# Altium schematic sheet RUMBA_plus.SchDoc (continued)

## Library data of the parts
5V 12V FAN0 FAN1 PS_ON PWR PWR2 = Header 2: Header, 2-Pin; Manufacturer 1: Generic Header; Note: Approved sub OK; LatestRevisionDate: 17-Jul-2002; LatestRevisionNote: Re-released for DXP Platform.; Signal Integrity: Connector; Library Name: RUMBA_v2.SCHLIB; Publisher: Altium Limited
  5V 12V FAN0 FAN1 PWR PWR2: Component Kind: Standard
C1 C2 C21-C25 C30 C31 = CapE-6.3-100uF-35V: Polarized Capacitor (Surface Mount); Note: Approved sub OK; Component Kind: Standard; Published: 8-Jun-2000; Revision: July-2002: Re-released for DXP Platform.; Signal Integrity: Cap Pol; Simulation: CAP; Supplier 1: Digi-Key; Supplier Part Number 1: PCE5016CT-ND; Library Name: RUMBA_v2.SCHLIB; Publisher: Altium Limited
C3 C9 C12 C26-C29 C35 C36 = CAPC_1u_0603_X7R_16V_10%: Capacitor SMD Cer 1uF 10% 16V X7R 0603; Manufacturer 1: TDK Corporation; Manufacturer Part Number 1: C1608X7R1C105K; Material: ceramic; Ratings: 16V; X7R; SCDType: spec; Component Kind: Standard; ComponentLink1Description: http://product.tdk.com/capacitor/mlcc/en/documents/mlcc_commercial_general_en.pdf; ComponentLink1URL: http://product.tdk.com/capacitor/mlcc/en/documents/mlcc_commercial_general_en.pdf; Library Name: PBL - Capacitors.SCHLIB; Note: Approved sub OK; Package / Case: 0603 (1608 metric); Supplier 1: Digi-Key; Supplier Part Number 1: 445-1604-1-ND; Tolerance: ±10%
C4-C7 C11 C13 C16 C18 = CapC-0603-100nF: Capacitor (Semiconductor SIM Model); Note: Approved sub OK; Component Kind: Standard; Published: 8-Jun-2000; Revision: July-2002: Re-released for DXP Platform.; Signal Integrity: Cap Semi; Simulation: CAP; Supplier 1: Digi-Key; Supplier Part Number 1: 311-1344-1-ND; Library Name: RUMBA_v2.SCHLIB; Publisher: Altium Limited
C8 C14 C15 C19 C20 = CapC-0603-22pF: Capacitor (Semiconductor SIM Model); Note: Approved sub OK; Component Kind: Standard; Published: 8-Jun-2000; Revision: July-2002: Re-released for DXP Platform.; Signal Integrity: Cap Semi; Simulation: CAP; Supplier 1: Digi-Key; Supplier Part Number 1: 478-6204-1-ND; Library Name: RUMBA_v2.SCHLIB; Publisher: Altium Limited
D1 D6 D7 D9-D14 = DIODE_100V_1.5A_SMA: Diode SMD 100V 1.5A SMA; Manufacturer 1: Diodes Inc; Manufacturer Part Number 1: S2BA-13-F; Diode Type: Standard; Material: Si; Note: Approved sub OK; SCDType: source; Value: Vf = 1.15V @ 1.5A (Max); Component Kind: Standard; Library Name: PBL - Diodes.SCHLIB; Package / Case: DO-214AC, SMA; Ratings: 100V; 1.5A; Supplier 1: Digi-Key; Supplier Part Number 1: S2BA-FDICT-ND
D2 D3 = DIODE_75V_500mW: Diode SMD 300mA 0.5W SOD323; Manufacturer: Micro Commercial Co; Manufacturer Part Number: 1N4148WX-TP; Diode Type: Standard; Material: Si; Note: Approved sub OK; Ratings: 75V; 300mA; 0.5W; SCDType: source; Value: Vf=1V @ 50mA; Component Kind: Standard; Library Name: PBL - Diodes.SCHLIB; Package / Case: SOD323; Supplier 1: Digi-Key; Supplier Part Number 1: 1N4148WXTPMSCT-ND
D4 = Diode Schottky 2A 20V Dual Common Cathode: Default Diode; Code - JEDEC: DO-214-AB; Note: Approved sub OK; VALUE: PMEG2020CPA,115; Published: 8-Jun-2000; Revision: July-2002: Re-released for DXP Platform.; Signal Integrity: Diode; Simulation: DIODE; Supplier 1: Digi-Key; Supplier Part Number 1: 1727-5194-1-ND; Library Name: RUMBA_v2.SCHLIB; Publisher: Altium Limited
D5 = Diode Schottky 2A 40V: Default Diode; Code - JEDEC: DO-214-AB; Note: Approved sub OK; Component Kind: Standard; Published: 8-Jun-2000; Revision: July-2002: Re-released for DXP Platform.; Signal Integrity: Diode; Simulation: DIODE; Supplier 1: Digi-Key; Supplier Part Number 1: DB2W40200LCT-ND; Library Name: picoprint_library.SCHLIB; Publisher: Altium Limited
E0 E1 X Y ZD ZG = A4988BREAKOUT: Manufacturer 1: Generic Header; Note: Approved sub OK; Component Kind: Standard; Description: Header, 8-Pin, Female; Library Name: RUMBA_v2.SCHLIB
E0_MOT E1_MOT E2_MOT X_MOT Y_MOT Z_MOT = Header 4_1: Header, 4-Pin; Note: Approved sub OK; LatestRevisionDate: 17-Jul-2002; LatestRevisionNote: Re-released for DXP Platform.; Signal Integrity: Connector; Supplier 1: Digi-Key; Supplier Part Number 1: 3M9449-ND; Library Name: RUMBA_v2.SCHLIB; Publisher: Altium Limited
E0_MOT_A E1_MOT_A E2_MOT_A X_MOT_A Y_MOT_A Z_MOT_A = ScrewTerminal-3.5mm-4Way: Screw Terminal 3.5mm 4-Way; Note: Approved sub OK; Component Kind: Standard; LatestRevisionDate: 17-Jul-2002; LatestRevisionNote: Re-released for DXP Platform.; Signal Integrity: Connector; Supplier 1: Digi-Key; Supplier Part Number 1: 277-5744-ND; Library Name: RUMBA_v2.SCHLIB; Publisher: Altium Limited
E0_MS E1_MS E2_MS X_MS Y_MS Z_MS = SW DIP-3: DIP Switch; Note: Approved sub OK; Component Kind: Standard; Published: 24-Mar-1999; Revision: July-2002: Re-released for DXP Platform.; Simulation: dpsw8; Supplier 1: Digi-Key; Supplier Part Number 1: 732-3853-1-ND; Library Name: RUMBA_v2.SCHLIB; Publisher: Altium Limited
ENC_1-ENC_3 = Header 4: Header, 4-Pin; Manufacturer 1: Generic Header; Note: Approved sub OK; LatestRevisionDate: 17-Jul-2002; LatestRevisionNote: Re-released for DXP Platform.; Library Name: Miscellaneous Connectors.IntLib; Signal Integrity: Connector; Publisher: Altium Limited
EXP1 EXP2 JTAG = Header 5X2: Header, 5-Pin, Dual row; Manufacturer 1: Generic Header; Note: Approved sub OK; LatestRevisionDate: 17-Jul-2002; LatestRevisionNote: Re-released for DXP Platform.; Signal Integrity: Connector; Library Name: RUMBA_v2.SCHLIB; Publisher: Altium Limited
  EXP1 EXP2: Component Kind: Standard
EXP3 = Header 8X2: Header, 10-Pin, Dual row; Note: Approved sub OK; Component Kind: Standard; LatestRevisionDate: 17-Jul-2002; LatestRevisionNote: Re-released for DXP Platform.; Signal Integrity: Connector; Library Name: picoprint_library.SCHLIB; Publisher: Altium Limited
F3 = Fuse - 15V 200mA Trip PTC SMD 0603: Manufacturer 1: Holly Fuse; Manufacturer Part Number 1: 06T-0160L; Note: Approved sub OK; Ratings: 160mA; 63VDC; slow-blow; SCDType: spec; Description: Fuse - 15V 200mA Trip PTC SMD 0603; Library Name: Schlib1.SchLib; Package / Case: 0603 (1608 metric); Supplier 1: Digi-Key; Supplier Part Number 1: 507-1821-1-ND
FAN0_1 FAN1_1 HE0-HE2 = ScrewTerminal-3.5mm-2Way: Note: Approved sub OK; Component Kind: Standard; Description: Screw Terminal 3.5mm 2-Way; Supplier 1: Digi-Key; Supplier Part Number 1: 277-5719-ND; Library Name: RUMBA_v2.SCHLIB
HB-OUT HB-PWR MAIN-PWR = ScrewTerminal-5mm-2Way: Note: Approved sub OK; Component Kind: Standard; Description: Screw Terminal 5mm 2-Way; Supplier 1: Digi-Key; Supplier Part Number 1: 277-6405-ND; Library Name: RUMBA_v2.SCHLIB
ISP1 ISP2 = Header 3X2: Header, 3-Pin, Dual row; Manufacturer 1: Generic Header; Note: Approved sub OK; LatestRevisionDate: 17-Jul-2002; LatestRevisionNote: Re-released for DXP Platform.; Signal Integrity: Connector; Library Name: RUMBA_v2.SCHLIB; Publisher: Altium Limited
J2 = Micro-USB B Socket: Note: Approved sub OK; Supplier 1: Digi-Key; Supplier Part Number 1: WM1399CT-ND; Library Name: picoprint_library.SCHLIB
L1 = Ferrite Bead SMD 0805: MagneticCore Inductor with Winding Polarity Marking; Note: Approved sub OK; Value: WE-CBF_0805 (WE-CBF); Component Kind: Standard; Published: 24-Mar-1999; Revision: July-2002: Re-released for DXP Platform.; Signal Integrity: Inductor Iron Dot; Supplier 1: Digi-Key; Supplier Part Number 1: 490-1054-1-ND; Library Name: RUMBA_v2.SCHLIB; Publisher: Altium Limited
L3 = Inductor SMD 33uH 2.5A: MagneticCore Inductor with Winding Polarity Marking; Note: Approved sub OK; Component Kind: Standard; Published: 24-Mar-1999; Revision: July-2002: Re-released for DXP Platform.; Supplier 1: Digi-Key; Supplier Part Number 1: SRN6045TA-330MCT-ND; Library Name: RUMBA_v2.SCHLIB; Publisher: Altium Limited
LD1-LD9 = LEDG  0603: Hyper-Bright LED; Note: Approved sub OK; Component Kind: Standard; Supplier 1: Digi-Key; Supplier Part Number 1: 160-1435-2-ND; Library Name: picoprint_library.SCHLIB
LED1 RX TX = LEDY  0603: Hyper-Bright LED; Note: Approved sub OK; Component Kind: Standard; Supplier 1: Digi-Key; Supplier Part Number 1: 160-1437-1-ND; Library Name: picoprint_library.SCHLIB
NT1 = JUMP_NETTIE: Component Kind: Net Tie; Description: Net Tie; Library Name: PBL - Pads and Jumpers.SCHLIB
OS_logo = Logo: Note: Approved sub OK; Library Name: gc.SCHLIB
Q1-Q5 = MOSFET - BUK9Y21-40E: Logic Level N MOSFET; Component Kind: Standard; Supplier 1: Digi-Key; Supplier Part Number 1: 1727-1123-1-ND; Library Name: RUMBA_library.SCHLIB
Q6 = MOSFET - PSMN1R8-40YLC: Logic Level N MOSFET; Component Kind: Standard; Supplier 1: Digi-Key; Supplier Part Number 1: 1727-1052-1-ND; Library Name: RUMBA_library.SCHLIB
R1 R9 = Res-0603-1M: Resistor; Note: Approved sub OK; Component Kind: Standard; Published: 8-Jun-2000; Revision: July-2002: Re-released for DXP Platform.; Signal Integrity: Res; Simulation: RESISTOR; Supplier 1: Digi-Key; Supplier Part Number 1: 311-1.00MHRDKR-ND; Library Name: RUMBA_v2.SCHLIB; Publisher: Altium Limited
R2 R3 R6 R11 R12 R21 R23 R25 R27 R29 R45 = Res-0603-10K: Resistor; Note: Approved sub OK; Component Kind: Standard; Published: 8-Jun-2000; Revision: July-2002: Re-released for DXP Platform.; Signal Integrity: Res; Simulation: RESISTOR; Supplier 1: Digi-Key; Supplier Part Number 1: 311-10.0KHRCT-ND; Library Name: RUMBA_v2.SCHLIB; Publisher: Altium Limited
R4 R13-R18 R20 R33 R36 R39 R50 R53 R55 R58 = Res-0603-1K: Resistor; Note: Approved sub OK; Component Kind: Standard; Published: 8-Jun-2000; Revision: July-2002: Re-released for DXP Platform.; Signal Integrity: Res; Simulation: RESISTOR; Supplier 1: Digi-Key; Supplier Part Number 1: 311-1.00KHRCT-ND; Library Name: RUMBA_v2.SCHLIB; Publisher: Altium Limited
R5 = Res-0603-53.6K: Resistor; Note: Approved sub OK; Component Kind: Standard; Published: 8-Jun-2000; Revision: July-2002: Re-released for DXP Platform.; Signal Integrity: Res; Simulation: RESISTOR; Supplier 1: Digi-Key; Supplier Part Number 1: 311-53.6KHRCT-ND; Library Name: RUMBA_library.SCHLIB; Publisher: Altium Limited
R7 R8 R31 R34 R37 R46 R48 R51 = Res-0603-22R: Resistor; Note: Approved sub OK; Component Kind: Standard; Published: 8-Jun-2000; Revision: July-2002: Re-released for DXP Platform.; Signal Integrity: Res; Simulation: RESISTOR; Supplier 1: Digi-Key; Supplier Part Number 1: 311-22.0HRCT-ND; Library Name: RUMBA_v2.SCHLIB; Publisher: Altium Limited
R22 R24 R26 R28 R30 R32 R35 R38 R44 R47 R49 R52 = Res-0603-100K: Resistor; Note: Approved sub OK; Component Kind: Standard; Published: 8-Jun-2000; Revision: July-2002: Re-released for DXP Platform.; Signal Integrity: Res; Simulation: RESISTOR; Supplier 1: Digi-Key; Supplier Part Number 1: 311-100KHRCT-ND; Library Name: RUMBA_v2.SCHLIB; Publisher: Altium Limited
R40-R43 R54 R60 R61 = Res-0603-4K7: Resistor; Note: Approved sub OK; Component Kind: Standard; Published: 8-Jun-2000; Revision: July-2002: Re-released for DXP Platform.; Signal Integrity: Res; Simulation: RESISTOR; Supplier 1: Digi-Key; Supplier Part Number 1: 311-4.7KGRCT-ND; Library Name: RUMBA_v2.SCHLIB; Publisher: Altium Limited
Reset = SW-PB: SMD Tactile Switch; Note: Approved sub OK; Supplier 1: Digi-Key; Supplier Part Number 1: 679-2396-1-ND; Library Name: RUMBA_v2.SCHLIB
sc1-sc4 = Sraf: Note: Approved sub OK; Library Name: gc.SCHLIB
Thermistors = Header 7X2: Header, 7-Pin, Dual row; Note: Approved sub OK; Component Kind: Standard; LatestRevisionDate: 17-Jul-2002; LatestRevisionNote: Re-released for DXP Platform.; Signal Integrity: Connector; Supplier 1: Digi-Key; Supplier Part Number 1: 3M15450-ND; Library Name: picoprint_library.SCHLIB; Publisher: Altium Limited
U1 = ATMEGA2560: The high-performance, low-power Atmel 8-bit AVR RISC-based microcontroller; Component Kind: Standard; Supplier 1: Digi-Key; Supplier Part Number 1: ATMEGA2560-16AU-ND; Library Name: RUMBA_v2.SCHLIB
U2 = ATMEGA16U2-MU: High Performance, Low Power USB2.0 AVR® 8-Bit Microcontroller; Component Kind: Standard; Supplier 1: Digi-Key; Supplier Part Number 1: ATMEGA16U2-AU-ND; Library Name: RUMBA_v2.SCHLIB
U3 = Buck Regulator AOZ1282CI: Buck Regulator; Component Kind: Standard; Library Name: Schlib1.SchLib; Supplier 1: Digi-Key; Supplier Part Number 1: 785-1613-1-ND
U5 = USBLC6-4SC6 USB ESD Protection: ESD Protection IC; Note: Approved sub OK; Component Kind: Standard; Supplier 1: Digi-Key; Supplier Part Number 1: 497-4492-1-ND; Library Name: picoprint_library.SCHLIB
USB_AUX = Header 5x1: Header, 5-Pin; Manufacturer 1: Generic Header; Note: Approved sub OK; LatestRevisionDate: 17-Jul-2002; LatestRevisionNote: Re-released for DXP Platform.; Signal Integrity: Connector; Library Name: picoprint_library.SCHLIB; Publisher: Altium Limited
X+ X- Y+ Y- Z+ Z- = Header 3: Header, 3-Pin; Manufacturer 1: Generic Header; Note: Approved sub OK; Component Kind: Standard; LatestRevisionDate: 17-Jul-2002; LatestRevisionNote: Re-released for DXP Platform.; Signal Integrity: Connector; Library Name: RUMBA_v2.SCHLIB; Publisher: Altium Limited
Y1 Y2 = XTAL_2: Crystal Oscillator; Manufacturer: Generic Components; Note: Approved sub OK; Class: Oscillator; Component Kind: Standard; Published: 08/06/2000; SubClass: Crystal Oscillator; Supplier 1: Digi-Key; Supplier Part Number 1: SER3686CT-ND; Library Name: RUMBA_v2.SCHLIB; Publisher: Altium Hobart Technology Centre
